# Altium schematic sheet i5_v6.0.SchDoc
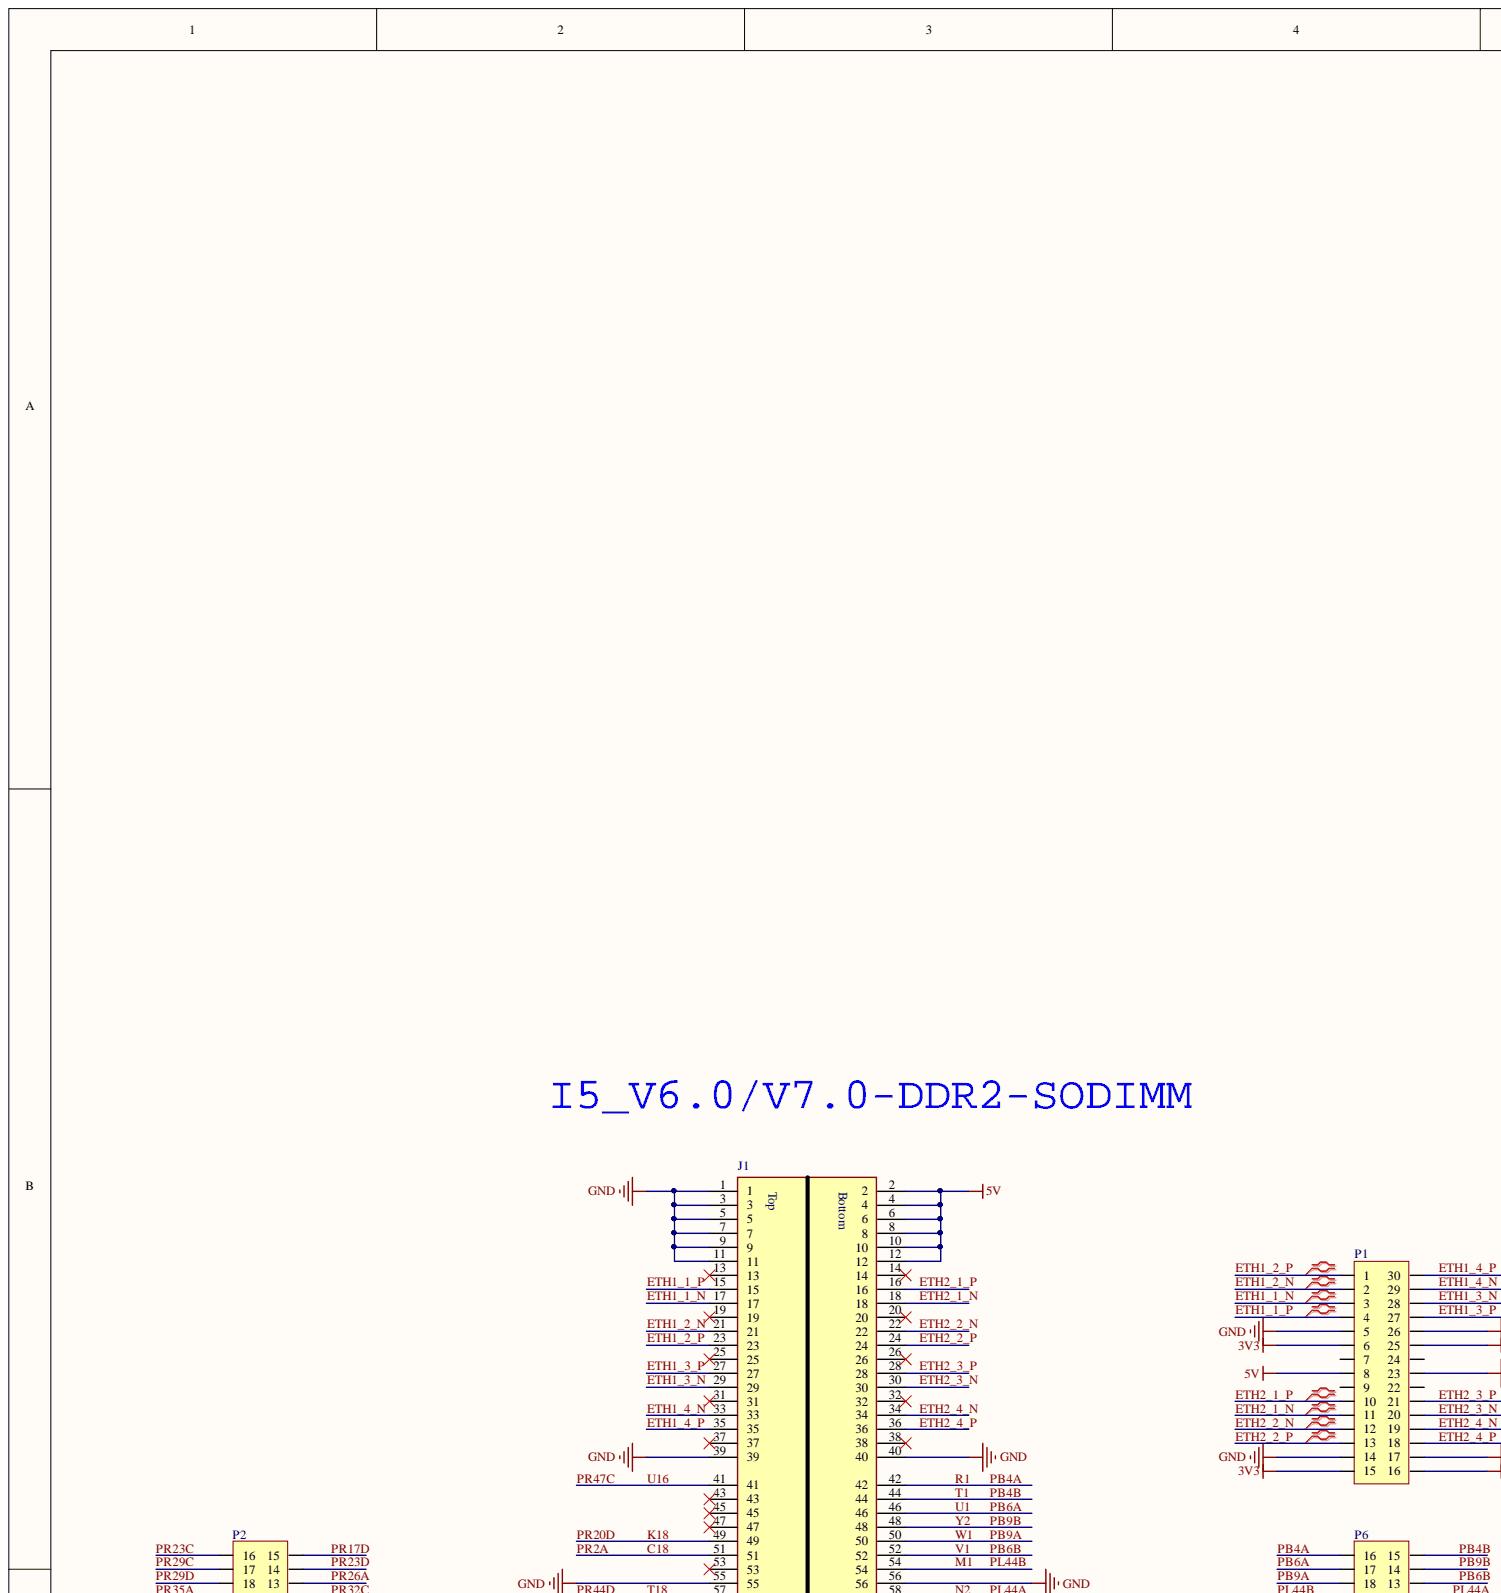
[diagram p0: i5_v6.0.SchDoc, top left]
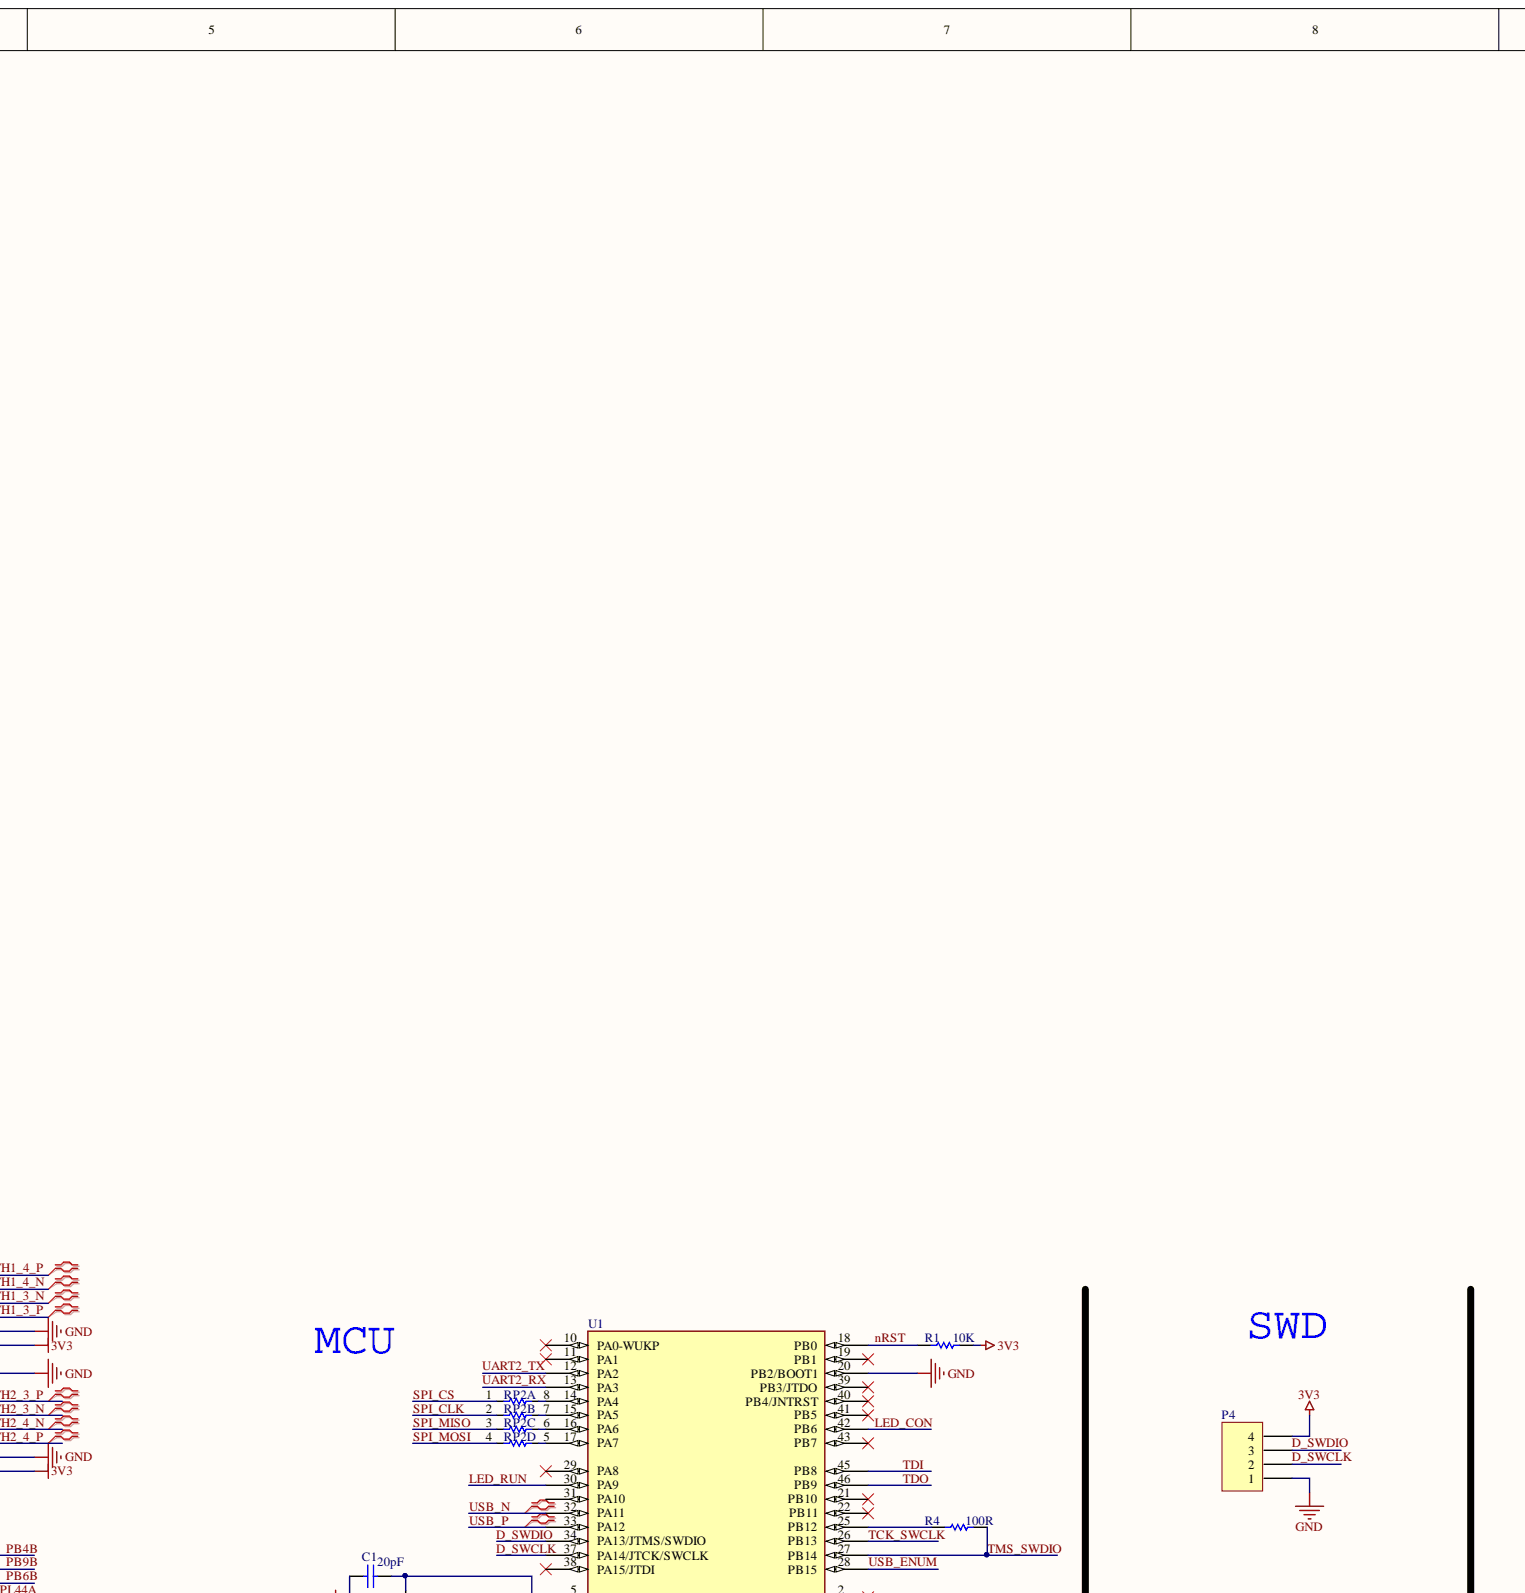
[diagram p1: i5_v6.0.SchDoc, top centre]
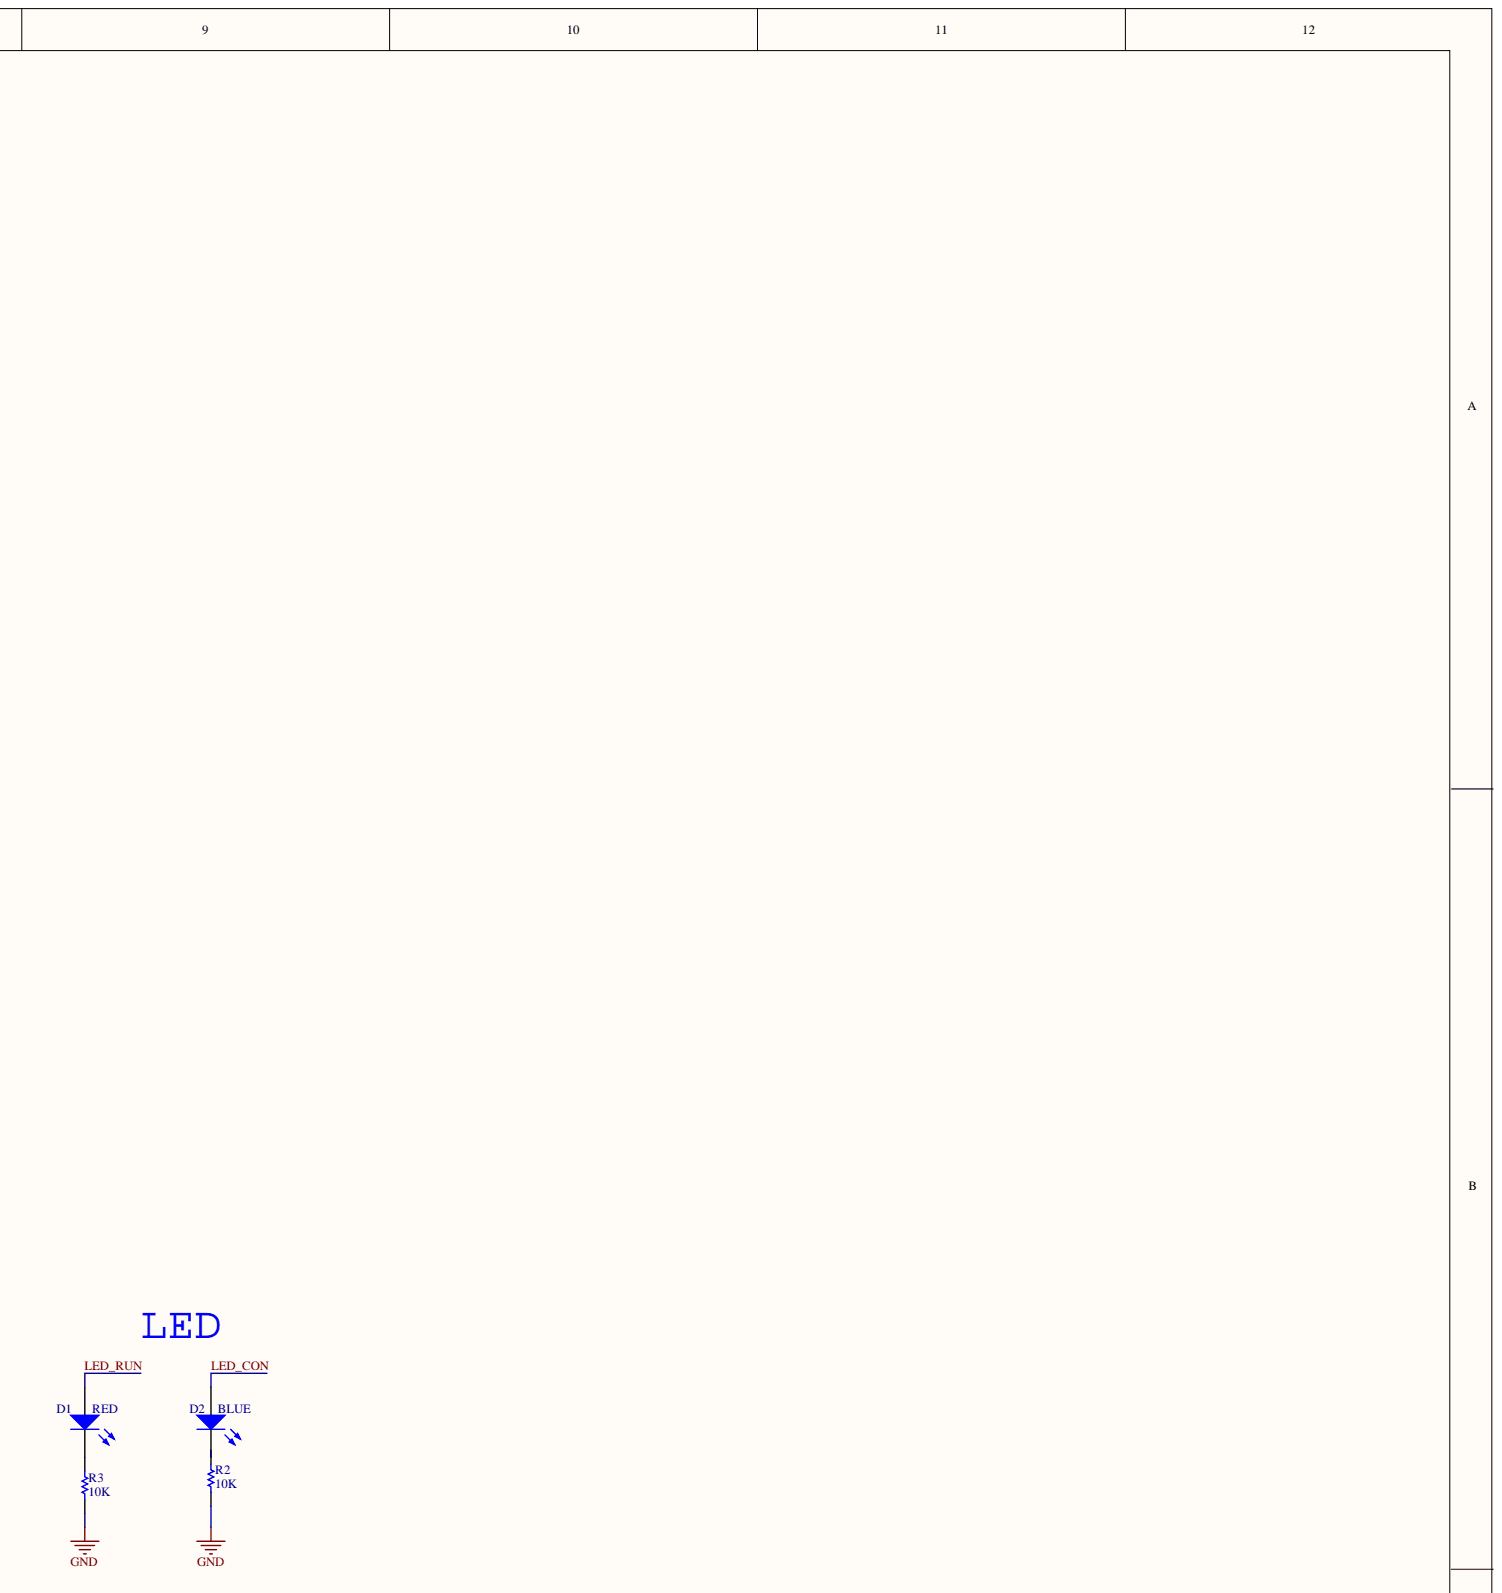
[diagram p2: i5_v6.0.SchDoc, top right]
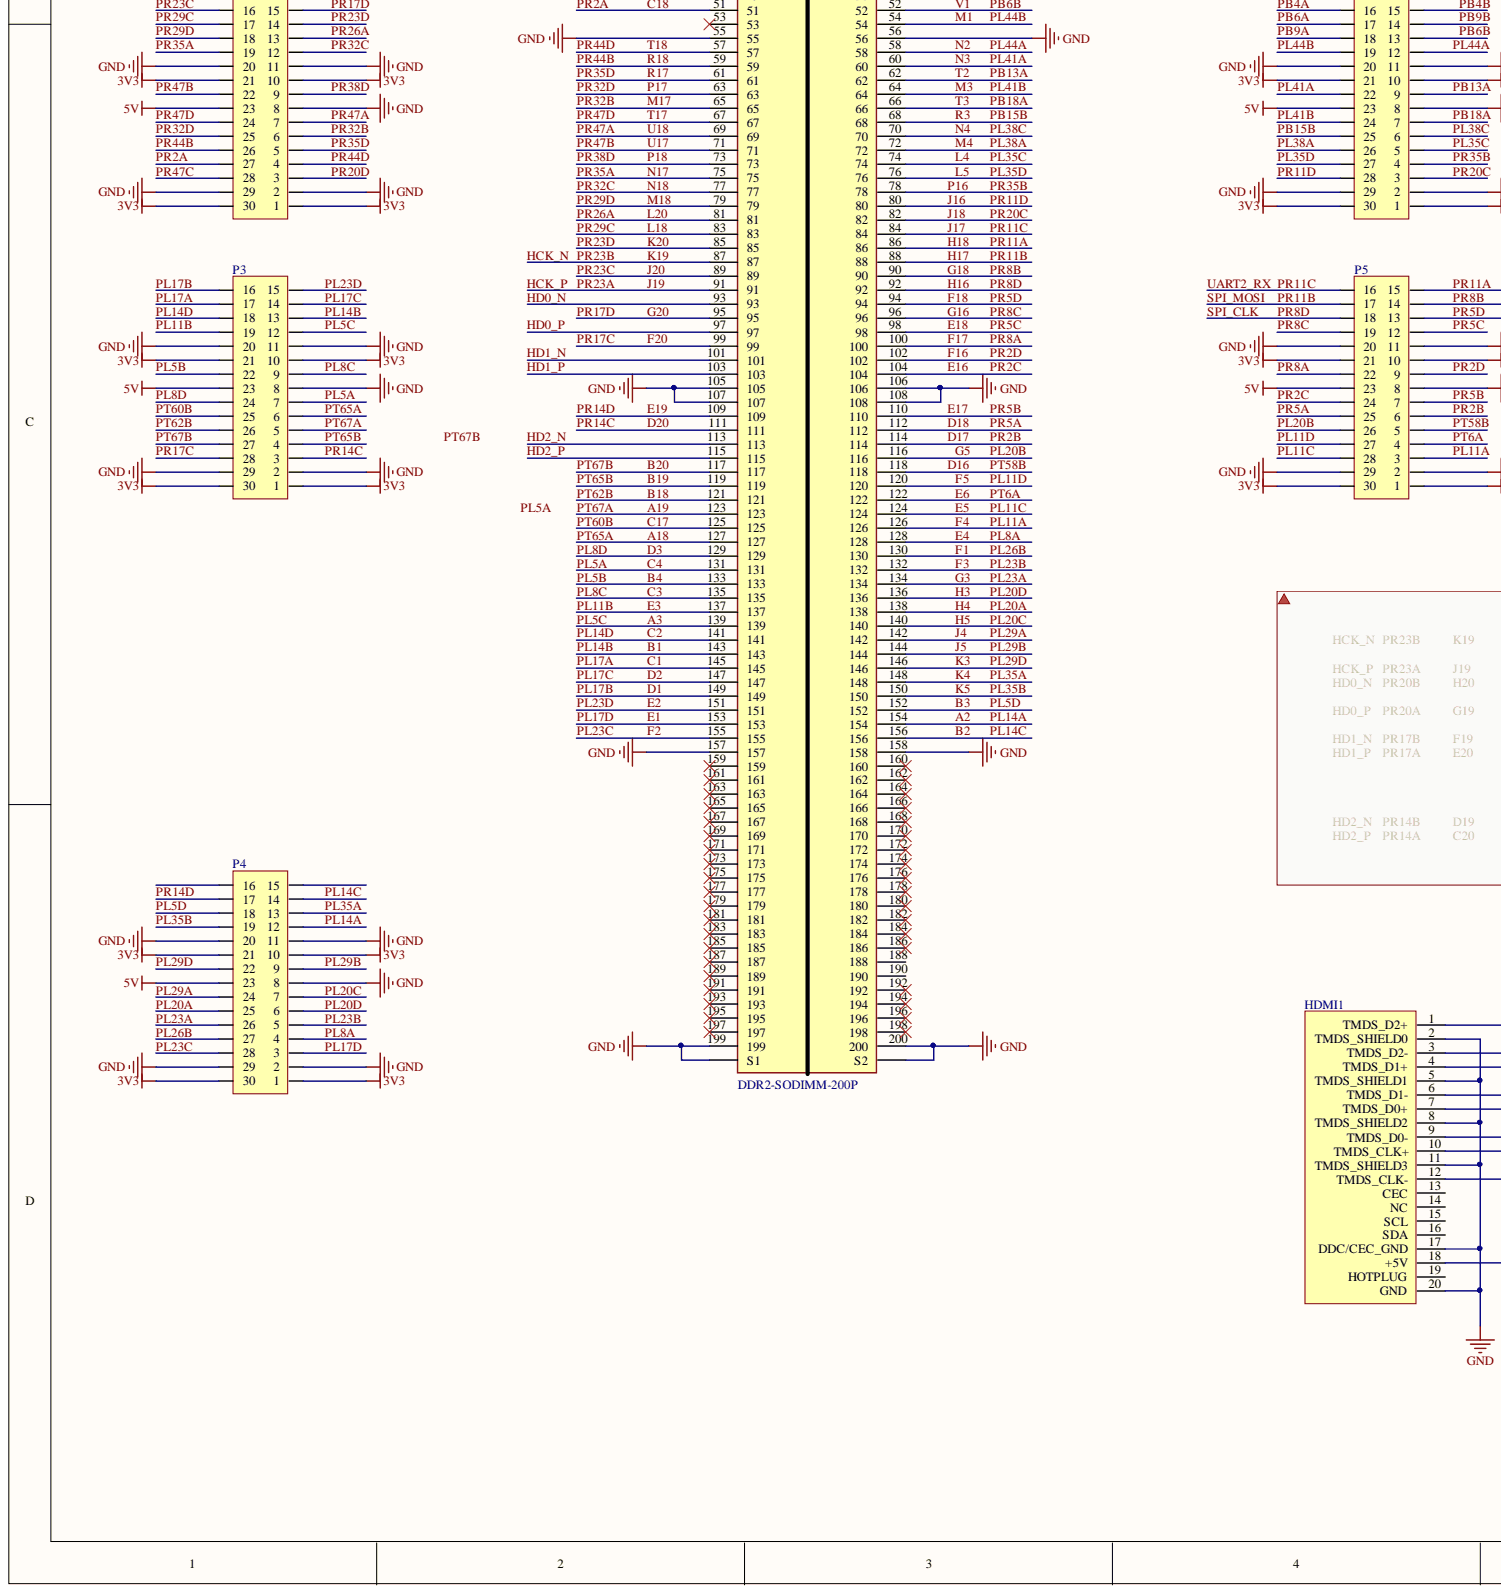
[diagram p3: i5_v6.0.SchDoc, bottom left]
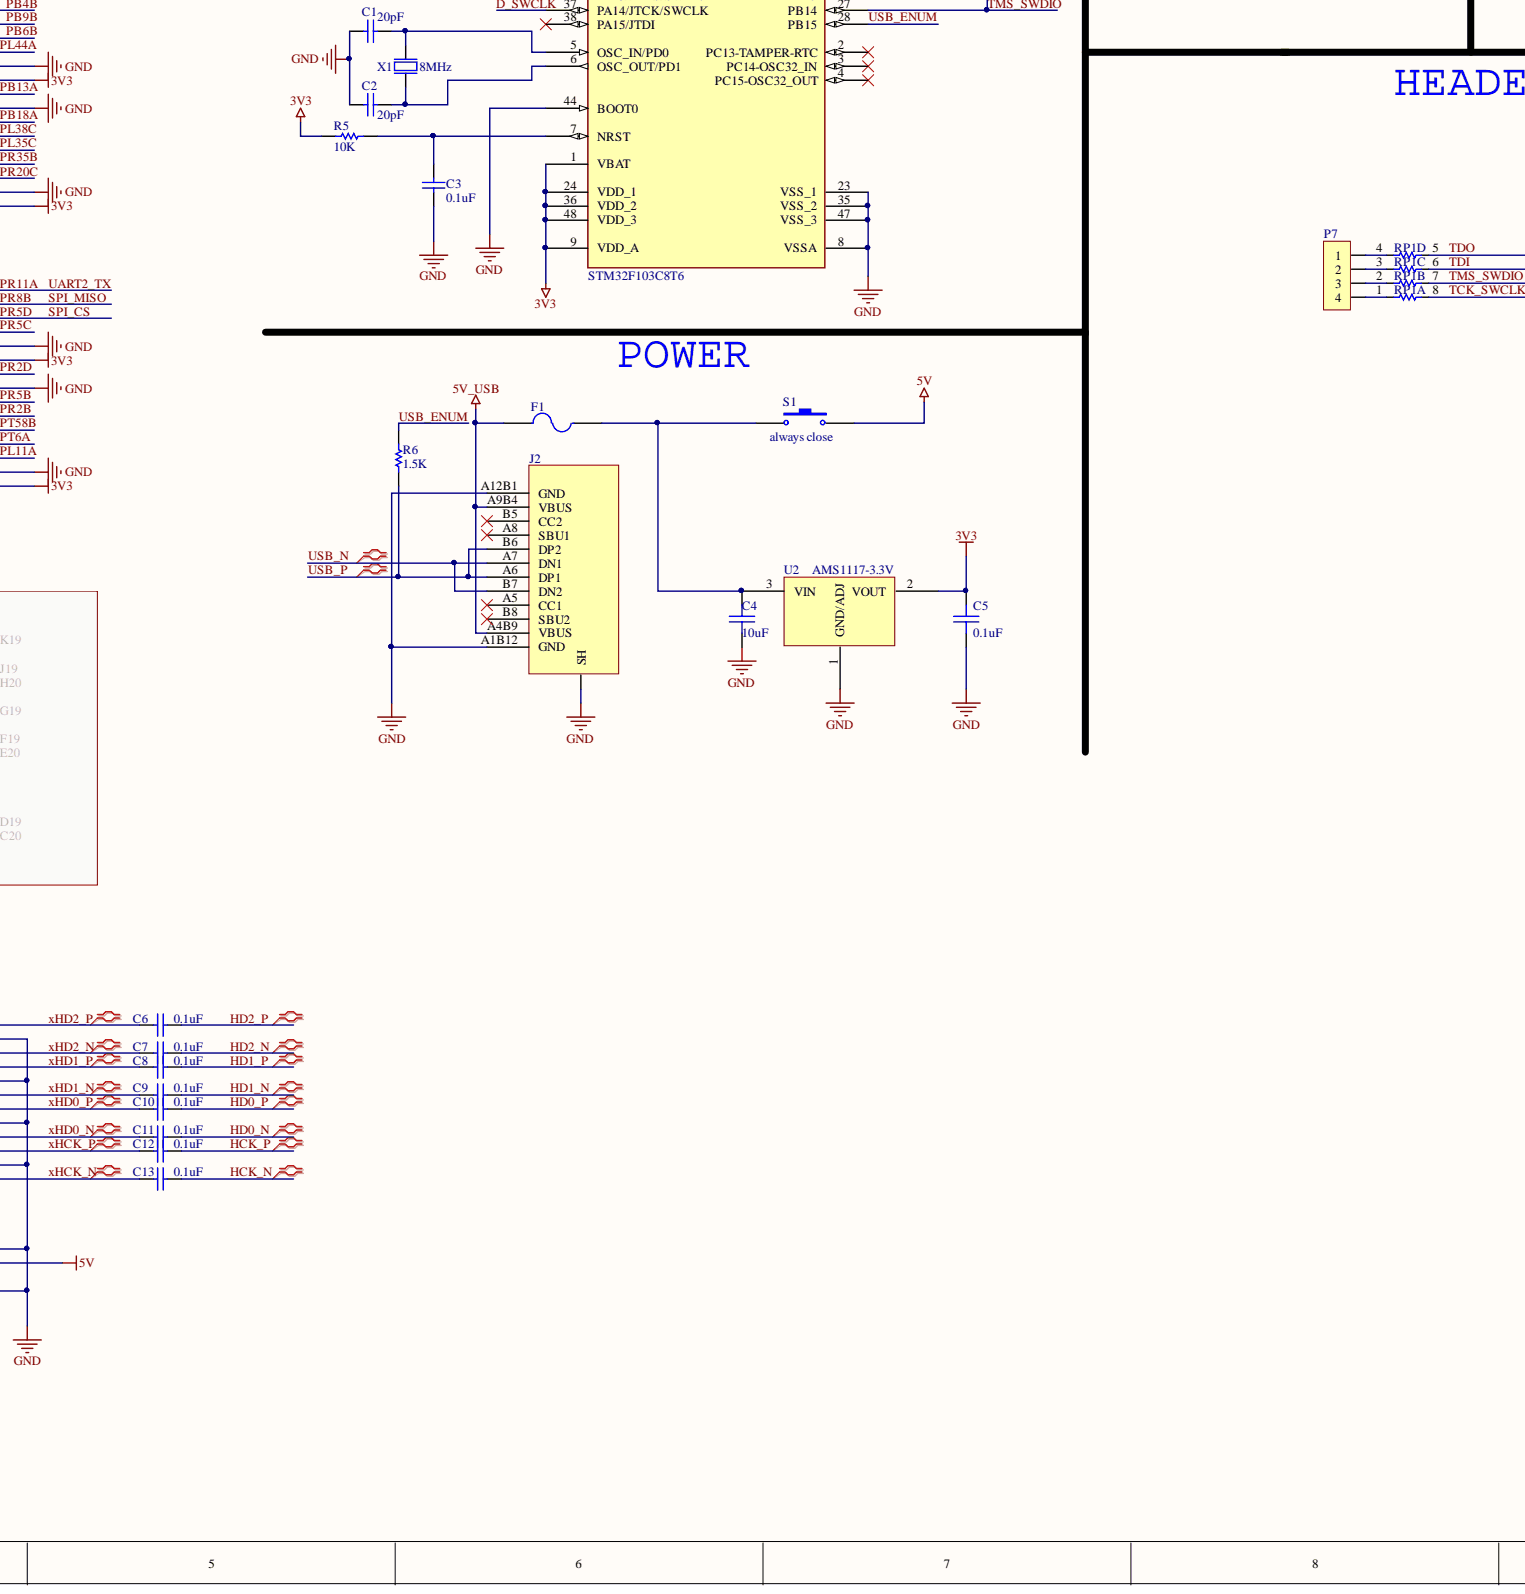
[diagram p4: i5_v6.0.SchDoc, bottom centre]
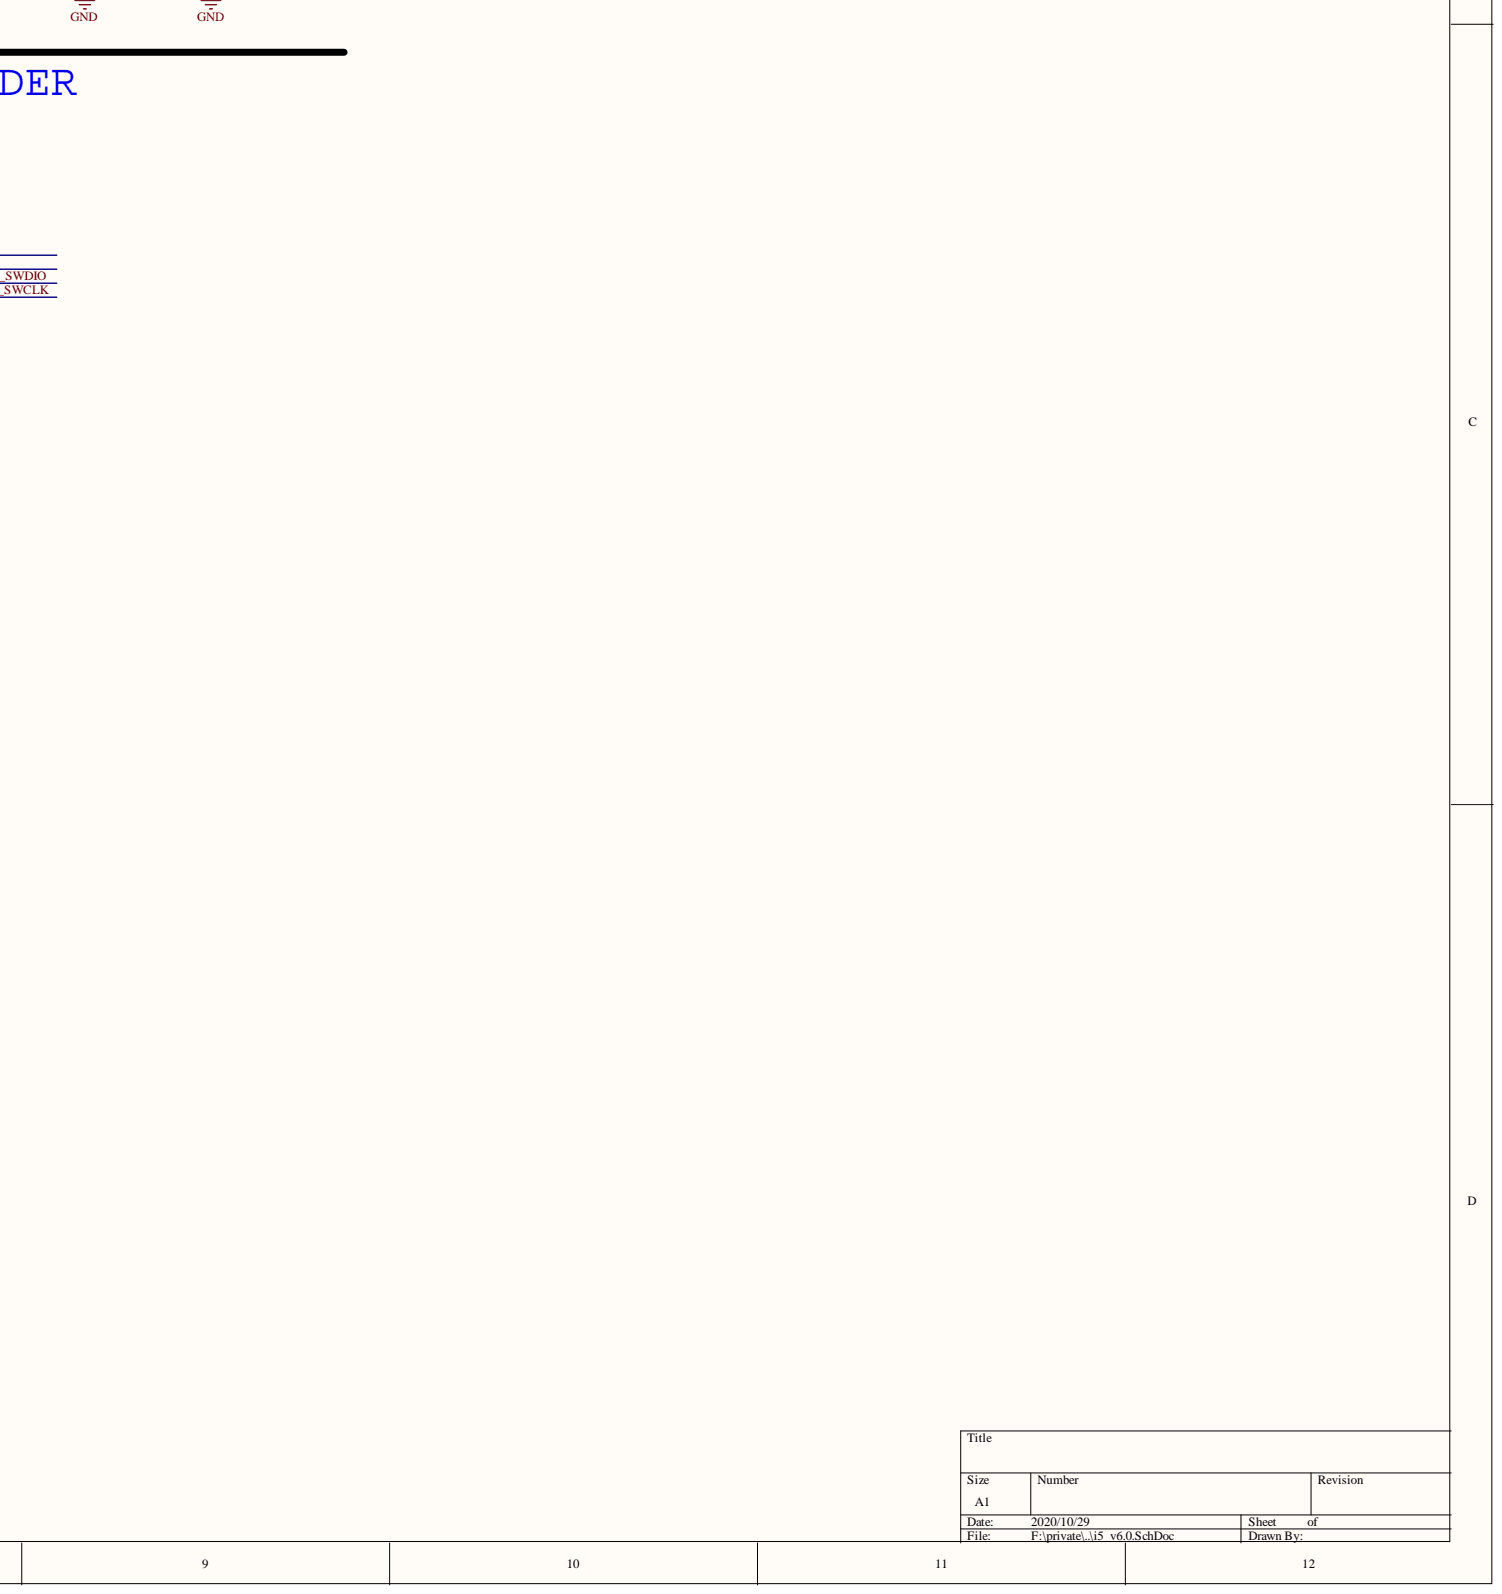
[diagram p5: i5_v6.0.SchDoc, bottom right]

## Parts
C1  value 20pF  [0603_C]  (hidden comment: C0603)
C2  value 20pF  [0603_C]  (hidden comment: C0603)
C3  value 0.1uF  [0603_C]  (hidden comment: C0603)
C4  10uF  [0603_C]
C5  0.1uF  [0603_C]
C6  value 0.1uF  [C 0402_M]  (hidden comment: C0603)
C7  value 0.1uF  [C 0402_M]  (hidden comment: C0603)
C8  value 0.1uF  [C 0402_M]  (hidden comment: C0603)
C9  value 0.1uF  [C 0402_M]  (hidden comment: C0603)
C10  value 0.1uF  [C 0402_M]  (hidden comment: C0603)
C11  value 0.1uF  [C 0402_M]  (hidden comment: C0603)
C12  value 0.1uF  [C 0402_M]  (hidden comment: C0603)
C13  value 0.1uF  [C 0402_M]  (hidden comment: C0603)
D1  RED  [0603_LED]
D2  BLUE  [0603_LED]
F1  Fuse 2  [FU 0805]  (value not drawn)
HDMI1  Header 20  [HDMI]  (value not drawn)
J1  DDR2-SODIMM-200P  [DDR2-SODIMM-200P]
J2  Type_C_16P  [Type_C_16P]  (value not drawn)
P1  Header 30  [HEADER_2x15]  (value not drawn)
P2  Header 30  [HEADER_2x15]  (value not drawn)
P3  Header 30  [HEADER_2x15]  (value not drawn)
P4  Header 4  [HEADER_1.27_4x1]  (value not drawn)
P4  Header 30  [HEADER_2x15]  (value not drawn)
P5  Header 30  [HEADER_2x15]  (value not drawn)
P6  Header 30  [HEADER_2x15]  (value not drawn)
P7  Header 4  [PROBE_4]  (value not drawn)
R1  value 10K  [0603_R]  (hidden comment: R0603)
R2  value 10K  [0603_R]  (hidden comment: R0603)
R3  value 10K  [0603_R]  (hidden comment: R0603)
R4  value 100R  [0603_R]  (hidden comment: R0603)
R5  value 10K  [0603_R]  (hidden comment: R0603)
R6  value 1.5K  [0603_R]  (hidden comment: R0603)
RP1  R_pack  [RCA-8P4R-0603]  (value not drawn)  (parts A,B,C,D)
RP2  R_pack  [RCA-8P4R-0603]  (value not drawn)  (parts A,B,C,D)
S1  always close  [TSW SMD-3*6*5]
U1  STM32F103C8T6  [LQFP48_N]
U2  AMS1117-3.3V  [SOT-89]
X1  8MHz  [BT-XTAL_3225]

## Nets
3V3: C5.1 P1.6 P1.15 P1.16 P1.25 P2.1 P2.10 P2.21 P2.30 P3.1 P3.10 P3.21 P3.30 P4.1 P4.4 P4.10 P4.21 P4.30 P5.1 P5.10 P5.21 P5.30 P6.1 P6.10 P6.21 P6.30 R1.1 R5.2 U1.1(VBAT) U1.9(VDD_A) U1.24(VDD_1) U1.36(VDD_2) U1.48(VDD_3) U2.2
5V: HDMI1.18(+5V) J1.2 J1.4 J1.6 J1.8 J1.10 J1.12 P1.8 P2.23 P3.23 P4.23 P5.23 P6.23 S1.2
5V_USB: F1.1 J2.A4B9(VBUS) J2.A9B4(VBUS)
GND: C1.2 C2.2 C3.1 C4.2 C5.2 HDMI1.2(TMDS_SHIELD0) HDMI1.5(TMDS_SHIELD1) HDMI1.8(TMDS_SHIELD2) HDMI1.11(TMDS_SHIELD3) HDMI1.17(DDC/CEC_GND) HDMI1.20(GND) J1.1 J1.3 J1.5 J1.7 J1.9 J1.11 J1.39 J1.40 J1.55 J1.56 J1.105 J1.106 J1.107 J1.108 J1.157 J1.158 J1.199 J1.200 J1.S1 J1.S2 J2.0(SH) J2.A1B12(GND) J2.A12B1(GND) P1.5 P1.14 P1.17 P1.23 P1.26 P2.2 P2.8 P2.11 P2.20 P2.29 P3.2 P3.8 P3.11 P3.20 P3.29 P4.1 P4.2 P4.8 P4.11 P4.20 P4.29 P5.2 P5.8 P5.11 P5.20 P5.29 P6.2 P6.8 P6.11 P6.20 P6.29 R2.1 R3.1 U1.8(VSSA) U1.20(PB2/BOOT1) U1.23(VSS_1) U1.35(VSS_2) U1.44(BOOT0) U1.47(VSS_3) U2.1 X1.2 X1.4
A2: J1.154 P4.12
A3: J1.139 P3.12
A18: J1.127 P3.6
A19: J1.123 P3.5
B1: J1.143 P3.13
B2: J1.156 P4.14
B3: J1.152 P4.18
B4: J1.133 P3.22
B18: J1.121 P3.26
B19: J1.119 P3.4
B20: J1.117 P3.27
C1: J1.145 P3.17
C2: J1.141 P3.18
C3: J1.135 P3.9
C4: J1.131 P3.7
C17: J1.125 P3.25
C18: J1.51 P2.27
D1: J1.149 P3.16
D2: J1.147 P3.14
D3: J1.129 P3.24
D16: J1.118 P5.5
D17: J1.114 P5.6
D18: J1.112 P5.25
D20: J1.111 P3.3
D_SWCLK: P4.2 U1.37(PA14/JTCK/SWCLK)
D_SWDIO: P4.3 U1.34(PA13/JTMS/SWDIO)
E1: J1.153 P4.3
E2: J1.151 P3.15
E3: J1.137 P3.19
E4: J1.128 P4.4
E5: J1.124 P5.28
E6: J1.122 P5.4
E16: J1.104 P5.24
E17: J1.110 P5.7
E18: J1.98 P5.12
E19: J1.109 P4.17
ETH1_1_N: J1.17 P1.3
ETH1_1_P: J1.15 P1.4
ETH1_2_N: J1.21 P1.2
ETH1_2_P: J1.23 P1.1
ETH1_3_N: J1.29 P1.28
ETH1_3_P: J1.27 P1.27
ETH1_4_N: J1.33 P1.29
ETH1_4_P: J1.35 P1.30
ETH2_1_N: J1.18 P1.11
ETH2_1_P: J1.16 P1.10
ETH2_2_N: J1.22 P1.12
ETH2_2_P: J1.24 P1.13
ETH2_3_N: J1.30 P1.20
ETH2_3_P: J1.28 P1.21
ETH2_4_N: J1.34 P1.19
ETH2_4_P: J1.36 P1.18
F1: J1.130 P4.27
F2: J1.155 P4.28
F3: J1.132 P4.5
F4: J1.126 P5.3
F5: J1.120 P5.27
F16: J1.102 P5.9
F17: J1.100 P5.22
F18: J1.94 P5.13 RP2.1
F20: J1.99 P3.28
G3: J1.134 P4.26
G5: J1.116 P5.26
G16: J1.96 P5.19
G18: J1.90 P5.14 RP2.3
G20: J1.95 P2.15
H3: J1.136 P4.6
H4: J1.138 P4.25
H5: J1.140 P4.7
H16: J1.92 P5.18 RP2.2
H17: J1.88 P5.17 RP2.4
H18: J1.86 P5.15 U1.12(PA2)
HCK_N: C13.1 J1.87
HCK_P: C12.1 J1.91
HD0_N: C11.1 J1.93
HD0_P: C10.1 J1.97
HD1_N: C9.1 J1.101
HD1_P: C8.1 J1.103
HD2_N: C7.1 J1.113
HD2_P: C6.1 J1.115
J4: J1.142 P4.24
J5: J1.144 P4.9
J16: J1.80 P6.28
J17: J1.84 P5.16 U1.13(PA3)
J18: J1.82 P6.3
J20: J1.89 P2.16
K3: J1.146 P4.22
K4: J1.148 P4.13
K5: J1.150 P4.19
K18: J1.49 P2.3
K20: J1.85 P2.14
L4: J1.74 P6.5
L5: J1.76 P6.27
L18: J1.83 P2.17
L20: J1.81 P2.13
LED_CON: D2.1 U1.42(PB6)
LED_RUN: D1.1 U1.30(PA9)
M1: J1.54 P6.19
M3: J1.64 P6.24
M4: J1.72 P6.26
M17: J1.65 P2.6
M18: J1.79 P2.18
N2: J1.58 P6.12
N3: J1.60 P6.22
N4: J1.70 P6.6
N17: J1.75 P2.19
N18: J1.77 P2.12
nRST: R1.2 U1.18(PB0)
P16: J1.78 P6.4
P17: J1.63 P2.25
P18: J1.73 P2.9
PB4A: J1.42 P6.16
PB4B: J1.44 P6.15
PB6A: J1.46 P6.17
PB6B: J1.52 P6.13
PB9A: J1.50 P6.18
PB9B: J1.48 P6.14
PB13A: J1.62 P6.9
PB15B: J1.68 P6.25
PB18A: J1.66 P6.7
PR35D: J1.61 P2.5
PR44B: J1.59 P2.26
PR44D: J1.57 P2.4
PR47A: J1.69 P2.7
PR47B: J1.71 P2.22
PR47C: J1.41 P2.28
PR47D: J1.67 P2.24
TCK_SWCLK: RP1.8 U1.26(PB13)
TDI: RP1.6 U1.45(PB8)
TDO: RP1.5 U1.46(PB9)
TMS_SWDIO: R4.1 RP1.7 U1.27(PB14)
USB_ENUM: R6.1 U1.28(PB15)
USB_N: J2.A7(DN1) J2.B7(DN2) U1.32(PA11)
USB_P: J2.A6(DP1) J2.B6(DP2) R6.2 U1.33(PA12)
xHCK_N: C13.2 HDMI1.12(TMDS_CLK-)
xHCK_P: C12.2 HDMI1.10(TMDS_CLK+)
xHD0_N: C11.2 HDMI1.9(TMDS_D0-)
xHD0_P: C10.2 HDMI1.7(TMDS_D0+)
xHD1_N: C9.2 HDMI1.6(TMDS_D1-)
xHD1_P: C8.2 HDMI1.4(TMDS_D1+)
xHD2_N: C7.2 HDMI1.3(TMDS_D2-)
xHD2_P: C6.2 HDMI1.1(TMDS_D2+)
NetC1_1: C1.1 U1.5(OSC_IN/PD0) X1.1
NetC2_1: C2.1 U1.6(OSC_OUT/PD1) X1.3
NetC3_2: C3.2 R5.1 U1.7(NRST)
NetC4_1: C4.1 F1.2 S1.1 U2.3
NetD1_2: D1.2 R3.2
NetD2_2: D2.2 R2.2
NetP7_1: P7.1 RP1.4
NetP7_2: P7.2 RP1.3
NetP7_3: P7.3 RP1.2
NetP7_4: P7.4 RP1.1
NetR4_2: R4.2 U1.25(PB12)
NetRP2_5: RP2.5 U1.17(PA7)
NetRP2_6: RP2.6 U1.16(PA6)
NetRP2_7: RP2.7 U1.15(PA5)
NetRP2_8: RP2.8 U1.14(PA4)

Not connected: HDMI1 13(CEC) 14(NC) 15(SCL) 16(SDA) 19(HOTPLUG); J1 13 14 19 20 25 26 31 32 37 38 43 45 47 53 159 160 161 162 163 164 165 166 167 168 169 170 171 172 173 174 175 176 177 178 179 180 181 182 183 184 185 186 187 188 189 190 191 192 193 194 195 196 197 198; J2 A5(CC1) A8(SBU1) B5(CC2) B8(SBU2); P1 7 9 22 24; P4 15 16; U1 2(PC13-TAMPER-RTC) 3(PC14-OSC32_IN) 4(PC15-OSC32_OUT) 10(PA0-WUKP) 11(PA1) 19(PB1) 21(PB10) 22(PB11) 29(PA8) 31(PA10) 38(PA15/JTDI) 39(PB3/JTDO) 40(PB4/JNTRST) 41(PB5) 43(PB7)

## Library data of the parts
C1-C3 C6-C13 = C0603: Capacitor; Component Kind: Standard; LatestRevisionDate: 17-Jul-2002; LatestRevisionNote: Re-released for DXP Platform.; Library Name: MYLIB.IntLib; PackageDescription: Radial Cap, Thru-Hole; 2 Leads; 0.3 in Pin Spacing; PackageReference: RAD-0.3; Published: 8-Jun-2000; Signal Integrity: Cap; Simulation: CAP; Publisher: Altium Limited
C4 = SMT_C_0805: Surface mount capacitor 0805; Component Kind: Standard; Library Name: Vowstar_Basic_Device.IntLib
C5 = SMT_C_0402: Surface mount capacitor 0402; Component Kind: Standard; Library Name: Vowstar_Basic_Device.IntLib
D1 D2 = D0603: Typical RED, GREEN, YELLOW, AMBER GaAs LED; Component Kind: Standard; LatestRevisionDate: 17-Jul-2002; LatestRevisionNote: Re-released for DXP Platform.; Library Name: MYLIB.IntLib; PackageDescription: SM LED; 2 Flat Leads; PackageReference: 3.2X1.6X1.1; PackageVersion: Feb-2002; Published: 8-Jun-2000; Simulation: LED2; Publisher: Altium Limited
F1 = Fuse 2: Fuse; Component Kind: Standard; LatestRevisionDate: 17-Jul-2002; LatestRevisionNote: Re-released for DXP Platform.; Library Name: Miscellaneous Devices.IntLib; PackageDescription: Thru-Hole; 2 Leads; PackageReference: PIN-W2/E2.8; Published: 8-Jun-2000; Simulation: FUSE; Publisher: Altium Limited
HDMI1 = HDMI: Header, 20-Pin; Component Kind: Standard; LatestRevisionDate: 17-Jul-2002; LatestRevisionNote: Re-released for DXP Platform.; Library Name: i5_v6.0.SCHLIB; Publisher: Altium Limited
P1-P6 = Header 2x15: Header, 30-Pin; Component Kind: Standard; LatestRevisionDate: 17-Jul-2002; LatestRevisionNote: Re-released for DXP Platform.; Library Name: i5_v6.0.SCHLIB; Signal Integrity: Connector; Publisher: Altium Limited
P4 = Header 4: Header, 4-Pin; Component Kind: Standard; LatestRevisionDate: 17-Jul-2002; LatestRevisionNote: Re-released for DXP Platform.; Library Name: Miscellaneous Connectors.IntLib; Signal Integrity: Connector; Publisher: Altium Limited
P7 = Header 1x4: Header, 4-Pin; Component Kind: Standard; LatestRevisionDate: 17-Jul-2002; LatestRevisionNote: Re-released for DXP Platform.; Library Name: i5_v6.0.SCHLIB; Signal Integrity: Connector; Publisher: Altium Limited
R1-R6 = R0603: Resistor; Component Kind: Standard; LatestRevisionDate: 17-Jul-2002; LatestRevisionNote: Re-released for DXP Platform.; Library Name: MYLIB.IntLib; PackageDescription: Axial Device, Thru-Hole; 2 Leads; 0.3 in Pin Spacing; PackageReference: AXIAL-0.3; Published: 8-Jun-2000; Signal Integrity: Res; Simulation: RESISTOR; Publisher: Altium Limited
S1 = SW-PB: Switch; Component Kind: Standard; LatestRevisionDate: 17-Jul-2002; LatestRevisionNote: Re-released for DXP Platform.; Library Name: Miscellaneous Devices.IntLib; PackageDescription: Switch; 2 Leads; PackageReference: SPST-2; Published: 24-Mar-1999; Publisher: Altium Limited
U2 = NCP1117: NCP1117, NCV1117. 1.0 A Low-Dropout Positive  Fixed and Adjustable  Voltage Regulators; Component Kind: Standard; Library Name: Vowstar_IC_General.IntLib
X1 = XTAL SMD 12MHz (3225): XTAL SMD 3225, 8MHz, 18pF, ±30ppm; 2nd source part number: 184-2056; Manufacturer: TXC Corporation; Manufacturer Part Number: 7M-12.000MAAJ-T; Artikkelnr Noca: 22019166; Artikkelnr Simpro: N.A.; Database Table Name: Crystal_Osc$; Note: XTAL SMD 12.000MHz; 2nd source Supplier: Farnell; Component Kind: Standard; Datasheet: http://www.txccrystal.com/images/pdf/7m.pdf; Footprint Doc: BT-XTAL_3225; LatestRevisionDate: 2010-08-31; Library Name: Nordic Discrete DB.DbLib; Part Number: XTAL SMD 12MHz (3225); Pin Count: 4; Published: 2010-08-31; Supplier: Digi-Key; Supplier part number: 887-1121-1-ND; Value: 8MHz; Publisher: Nordic Semiconductor
J1 = DDR2-SODIMM-200P: Component Kind: Standard; Library Name: i5_v6.0.SCHLIB
J2 = Type_C_16P: Component Kind: Standard; Library Name: ICESugar.SCHLIB
RP1 RP2 = R_pack: Component Kind: Standard; Library Name: nanoESP32S2.SCHLIB
U1 = STM32F103C8T6: Component Kind: Standard; Library Name: i5_v6.0.SCHLIB
